# Altium schematic sheet DashboardDisplay.SchDoc(DashboardDisplay)
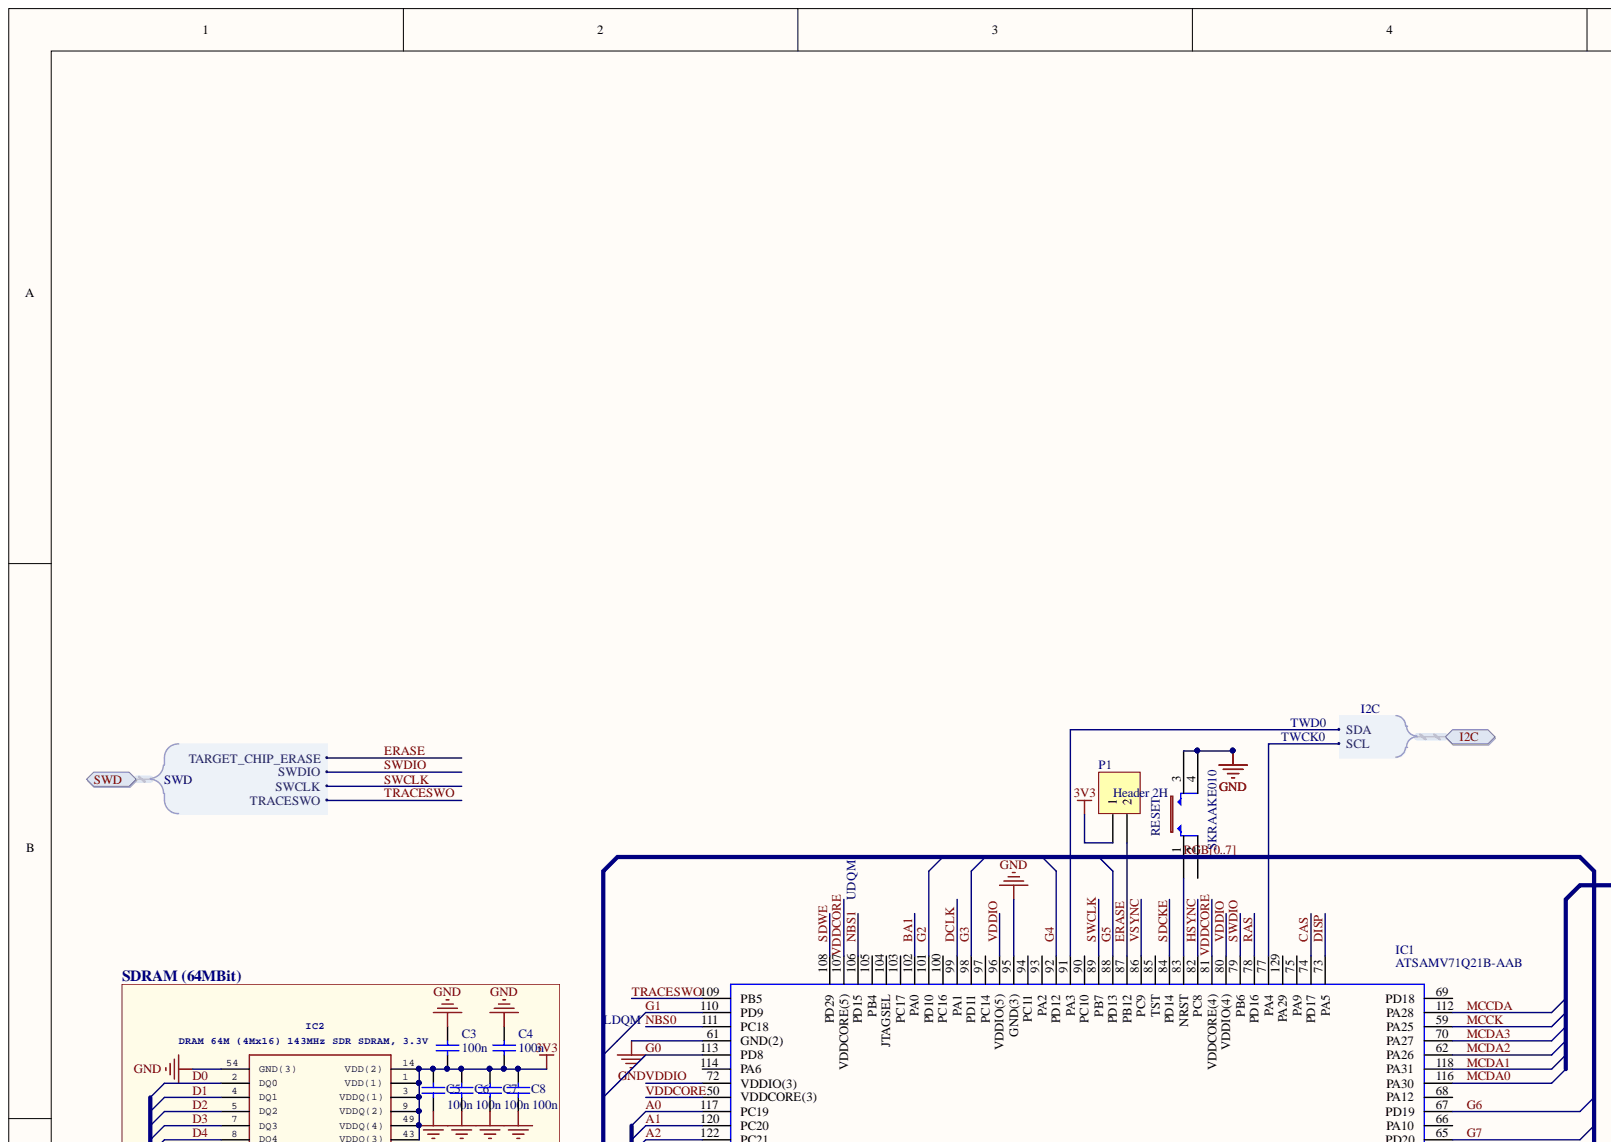
[diagram p0: DashboardDisplay.SchDoc, top left]
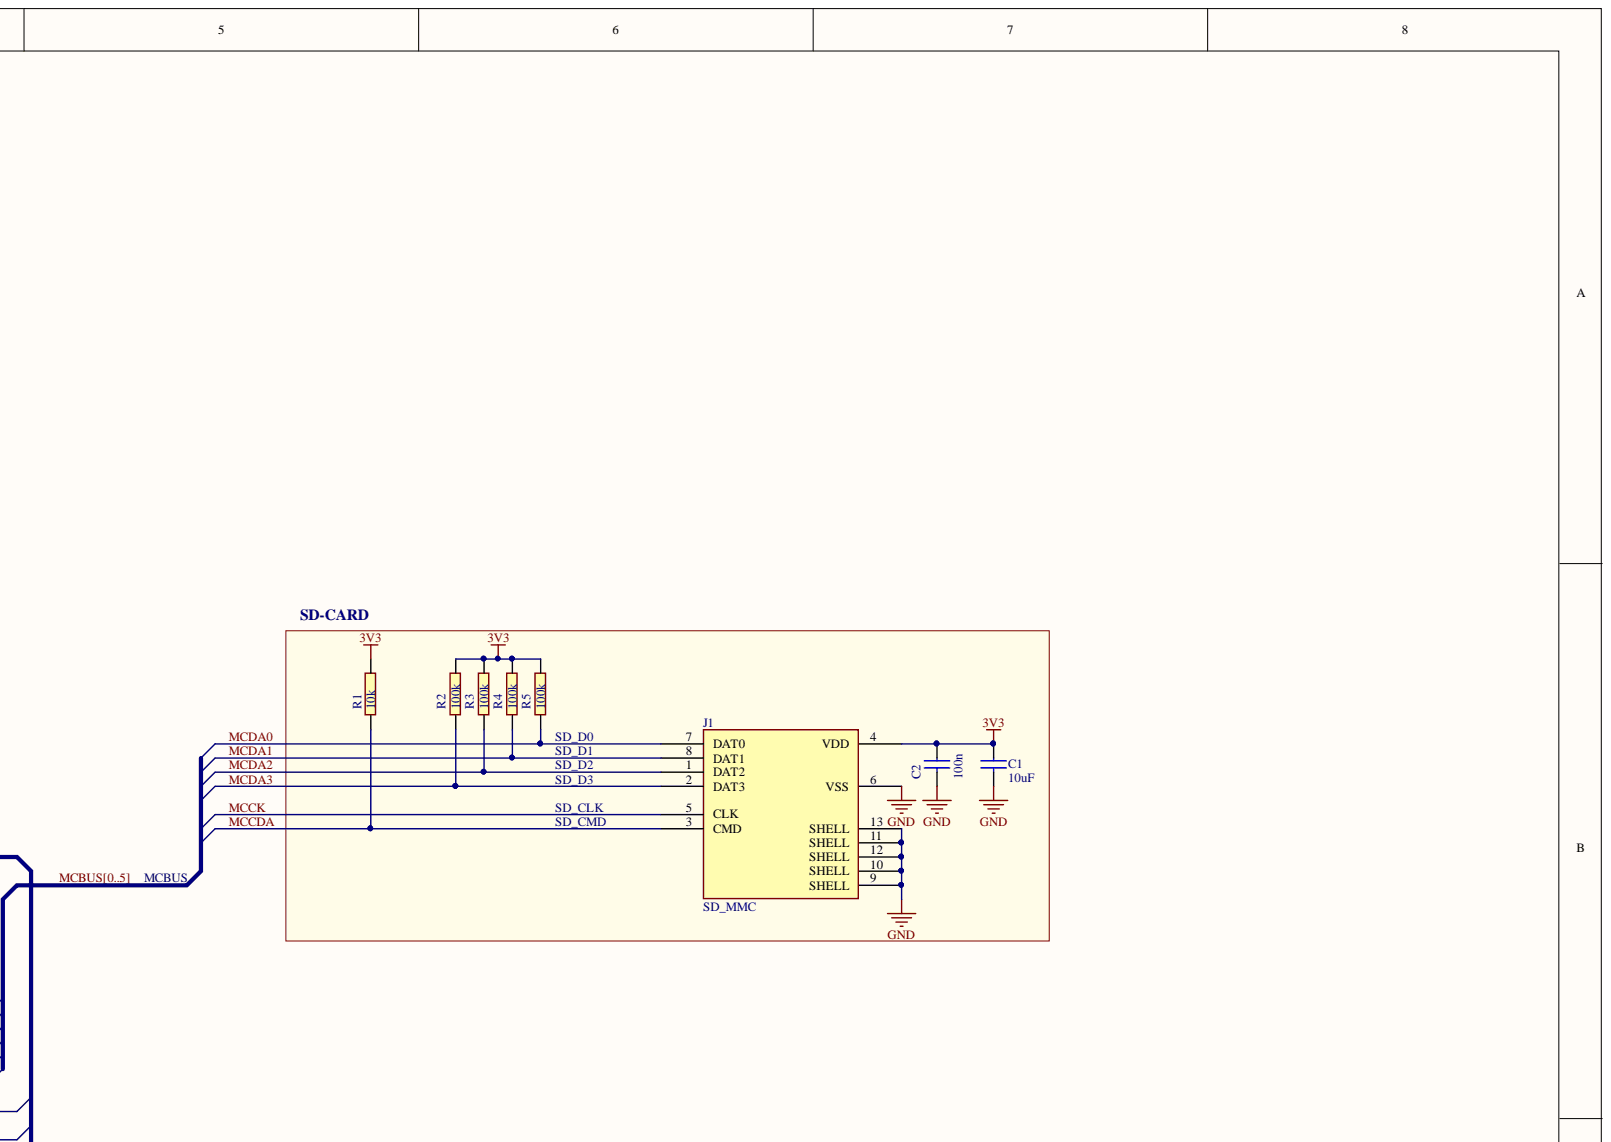
[diagram p1: DashboardDisplay.SchDoc, top right]
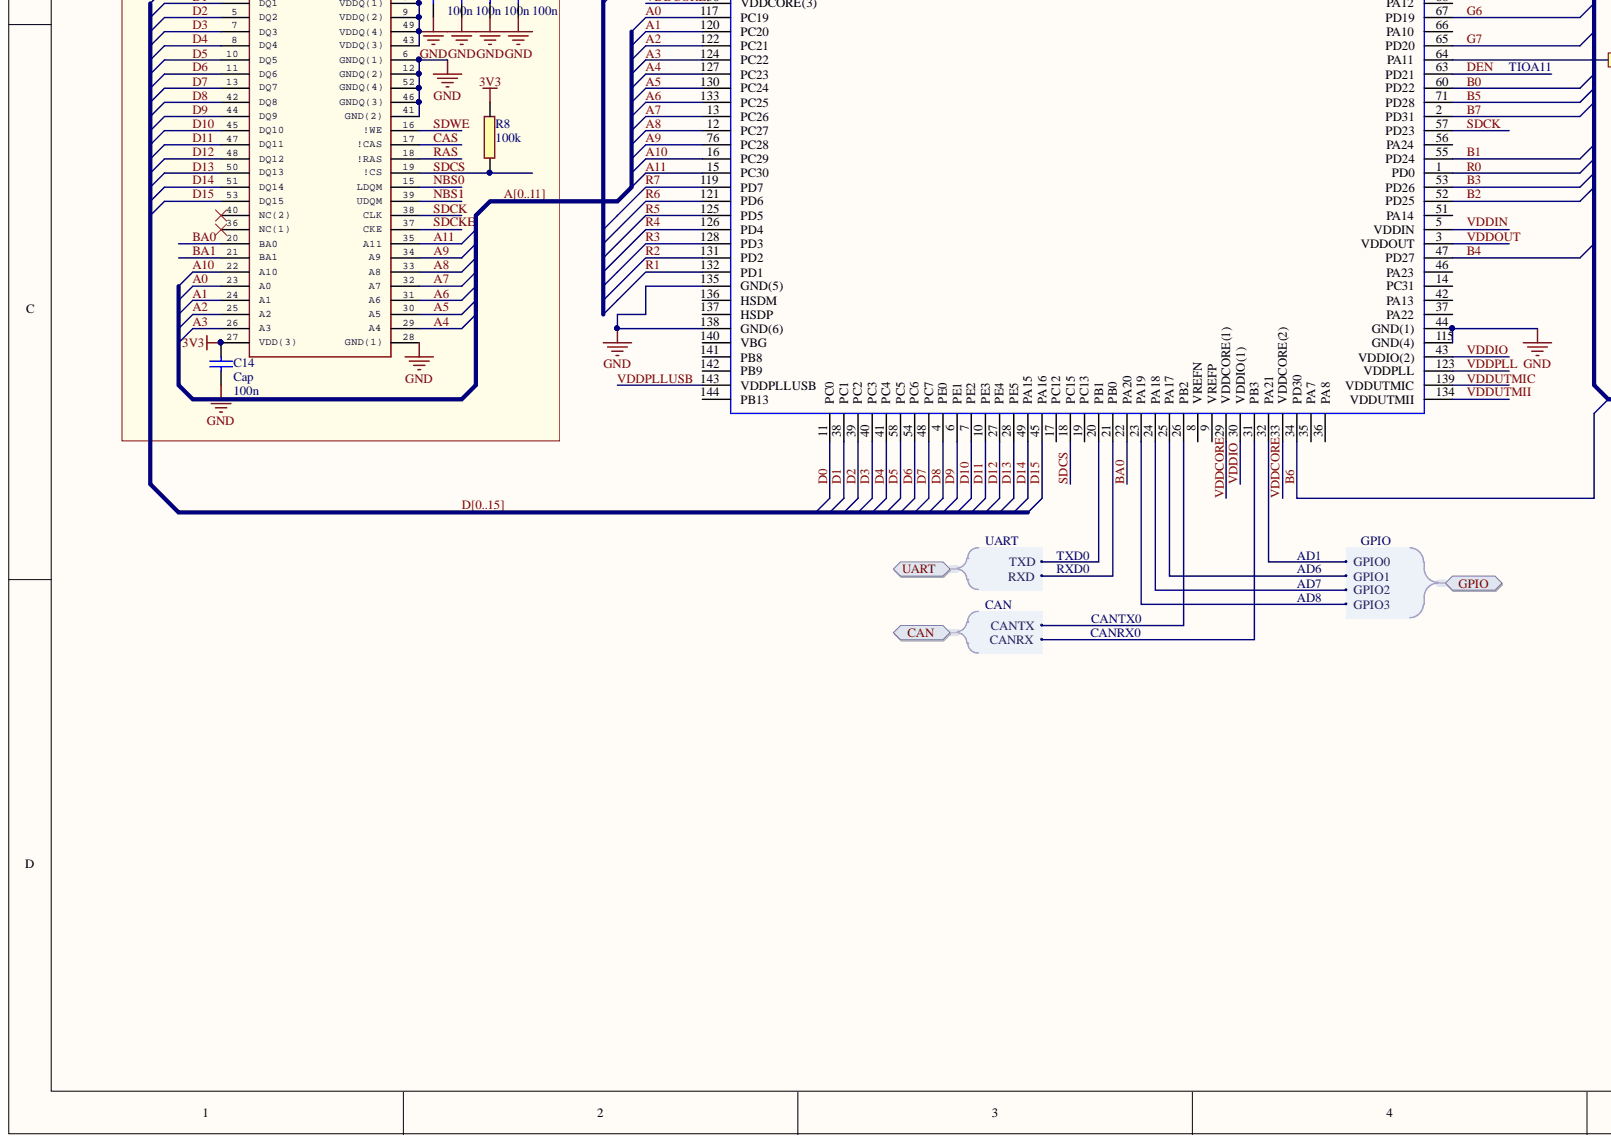
[diagram p2: DashboardDisplay.SchDoc, bottom left]
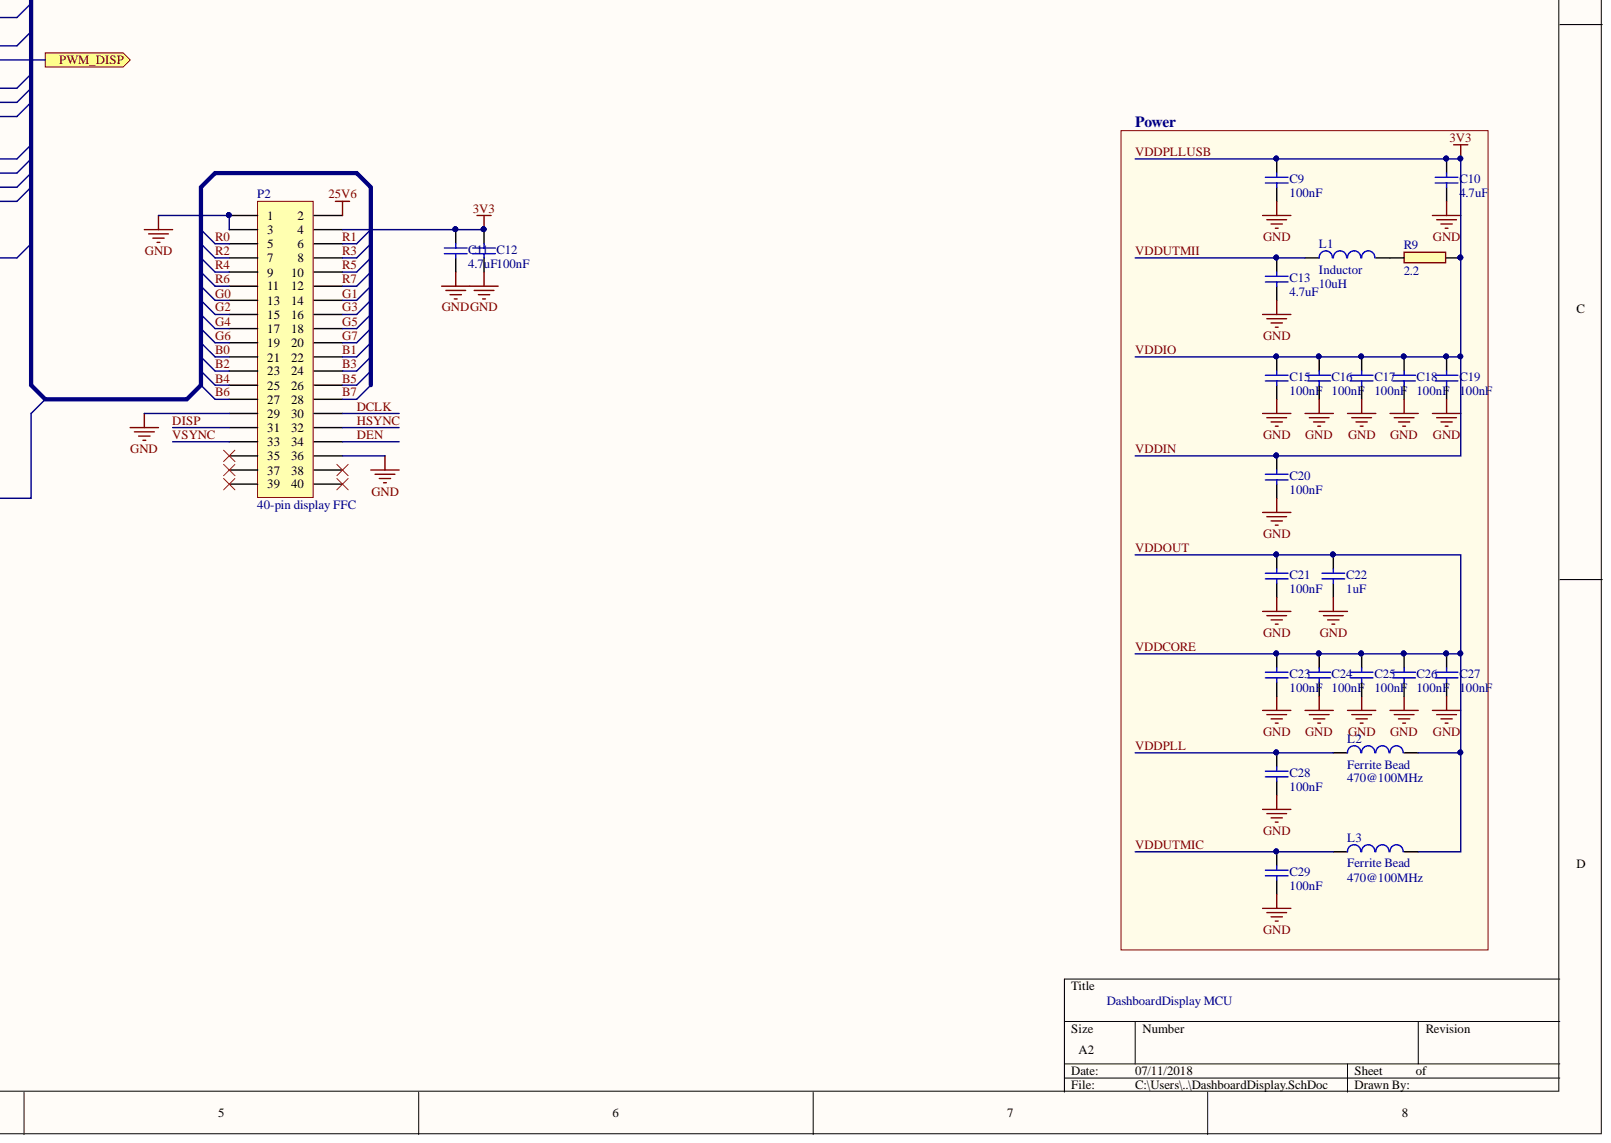
[diagram p3: DashboardDisplay.SchDoc, bottom right]

## Parts
C1  value 10uF  [C1206]
C2  value 100n  [1608[0603]]
C3  value 100n  [1608[0603]]
C4  value 100n  [1608[0603]]
C5  value 100n  [1608[0603]]
C6  value 100n  [1608[0603]]
C7  value 100n  [1608[0603]]
C8  value 100n  [1608[0603]]
C9  value 100nF  [1608[0603]]  (hidden comment: Cap Semi)
C10  value 4.7uF  [C1206]  (hidden comment: Cap Semi)
C11  value 4.7uF  [C1206]  (hidden comment: Cap Semi)
C12  value 100nF  [1608[0603]]  (hidden comment: Cap Semi)
C13  value 4.7uF  [C1206]  (hidden comment: Cap Semi)
C14  Cap  value 100n  [1608[0603]]
C15  value 100nF  [1608[0603]]  (hidden comment: Cap Semi)
C16  value 100nF  [1608[0603]]  (hidden comment: Cap Semi)
C17  value 100nF  [1608[0603]]  (hidden comment: Cap Semi)
C18  value 100nF  [1608[0603]]  (hidden comment: Cap Semi)
C19  value 100nF  [1608[0603]]  (hidden comment: Cap Semi)
C20  value 100nF  [1608[0603]]  (hidden comment: Cap Semi)
C21  value 100nF  [1608[0603]]  (hidden comment: Cap Semi)
C22  value 1uF  [1608[0603]]  (hidden comment: Cap Semi)
C23  value 100nF  [1608[0603]]  (hidden comment: Cap Semi)
C24  value 100nF  [1608[0603]]  (hidden comment: Cap Semi)
C25  value 100nF  [1608[0603]]  (hidden comment: Cap Semi)
C26  value 100nF  [1608[0603]]  (hidden comment: Cap Semi)
C27  value 100nF  [1608[0603]]  (hidden comment: Cap Semi)
C28  value 100nF  [1608[0603]]  (hidden comment: Cap Semi)
C29  value 100nF  [1608[0603]]  (hidden comment: Cap Semi)
IC1  ATSAMV71Q21B-AAB  [QFP50P2200X2200X160-144N]
IC2  DRAM 64M (4Mx16) 143MHz SDR SDRAM, 3.3V  [SOP80P1176X120-54N]
J1  SD_MMC  [DM3AT-SF-PEJM5(40)]
L1  Inductor  value 10uH  [1608[0603]]
L2  Ferrite Bead  value 470@100MHz  [INDC1608L]
L3  Ferrite Bead  value 470@100MHz  [INDC1608L]
P1  Header 2H  [14-1210]
P2  40-pin display FFC  [54132-4062_1]
R1  value 10k  [6-0805_L]
R2  value 100k  [6-0805_L]
R3  value 100k  [6-0805_L]
R4  value 100k  [6-0805_L]
R5  value 100k  [6-0805_L]
R8  value 100k  [6-0805_L]
R9  value 2.2  [6-0805_L]
RESET  value SKRAAKE010  [SKRAAKE010_1]

## Ports
CAN, CAN.CANRX, CAN.CANTX, GPIO, GPIO.GPIO0, GPIO.GPIO1, GPIO.GPIO2, GPIO.GPIO3, I2C, I2C.SCL, I2C.SDA, PWM_DISP, SWD, SWD.SWCLK, SWD.SWDIO, SWD.TARGET_CHIP_ERASE, SWD.TRACESWO, UART, UART.RXD, UART.TXD

## Nets
25V6: P2.2
GND: C1.2 C2.2 C3.2 C4.2 C5.1 C6.1 C7.1 C8.1 C9.1 C10.1 C11.1 C12.1 C13.1 C14.1 C15.1 C16.1 C17.1 C18.1 C19.1 C20.1 C21.1 C22.1 C23.1 C24.1 C25.1 C26.1 C27.1 C28.1 C29.1 IC1.44(GND(1)) IC1.61(GND(2)) IC1.95(GND(3)) IC1.115(GND(4)) IC1.135(GND(5)) IC1.138(GND(6)) IC2.6(GNDQ(1)) IC2.12(GNDQ(2)) IC2.28(GND(1)) IC2.41(GND(2)) IC2.46(GNDQ(3)) IC2.52(GNDQ(4)) IC2.54(GND(3)) J1.6(VSS) J1.9(SHELL) J1.10(SHELL) J1.11(SHELL) J1.12(SHELL) J1.13(SHELL) P2.1 P2.3 P2.29 P2.36 RESET.3 RESET.4
A0: IC1.117(PC19) IC2.23(A0)  [also labelled A]
A1: IC1.120(PC20) IC2.24(A1)  [also labelled A]
A2: IC1.122(PC21) IC2.25(A2)  [also labelled A]
A3: IC1.124(PC22) IC2.26(A3)  [also labelled A]
A4: IC1.127(PC23) IC2.29(A4)  [also labelled A]
A5: IC1.130(PC24) IC2.30(A5)  [also labelled A]
A6: IC1.133(PC25) IC2.31(A6)  [also labelled A]
A7: IC1.13(PC26) IC2.32(A7)  [also labelled A]
A8: IC1.12(PC27) IC2.33(A8)  [also labelled A]
A9: IC1.76(PC28) IC2.34(A9)  [also labelled A]
A10: IC1.16(PC29) IC2.22(A10)  [also labelled A]
A11: IC1.15(PC30) IC2.35(A11)  [also labelled A]
B0: IC1.60(PD22) P2.21
B1: IC1.55(PD24) P2.22
B2: IC1.52(PD25) P2.23
B3: IC1.53(PD26) P2.24
B4: IC1.47(PD27) P2.25
B5: IC1.71(PD28) P2.26
B6: IC1.34(PD30) P2.27
B7: IC1.2(PD31) P2.28
BA0: IC1.22(PA20) IC2.20(BA0)
BA1: IC1.102(PA0) IC2.21(BA1)
CAS: IC1.74(PD17) IC2.17(!CAS)
D0: IC1.11(PC0) IC2.2(DQ0)  [also labelled D]
D1: IC1.38(PC1) IC2.4(DQ1)  [also labelled D]
D2: IC1.39(PC2) IC2.5(DQ2)  [also labelled D]
D3: IC1.40(PC3) IC2.7(DQ3)  [also labelled D]
D4: IC1.41(PC4) IC2.8(DQ4)  [also labelled D]
D5: IC1.58(PC5) IC2.10(DQ5)  [also labelled D]
D6: IC1.54(PC6) IC2.11(DQ6)  [also labelled D]
D7: IC1.48(PC7) IC2.13(DQ7)  [also labelled D]
D8: IC1.4(PE0) IC2.42(DQ8)  [also labelled D]
D9: IC1.6(PE1) IC2.44(DQ9)  [also labelled D]
D10: IC1.7(PE2) IC2.45(DQ10)  [also labelled D]
D11: IC1.10(PE3) IC2.47(DQ11)  [also labelled D]
D12: IC1.27(PE4) IC2.48(DQ12)  [also labelled D]
D13: IC1.28(PE5) IC2.50(DQ13)  [also labelled D]
D14: IC1.49(PA15) IC2.51(DQ14)  [also labelled D]
D15: IC1.45(PA16) IC2.53(DQ15)  [also labelled D]
DCLK: IC1.99(PA1) P2.30
DEN: IC1.63(PD21) P2.34
DISP: IC1.73(PA5) P2.31
ERASE: IC1.87(PB12) P1.2 ; port SWD
G0: IC1.113(PD8) P2.13
G1: IC1.110(PD9) P2.14
G2: IC1.101(PD10) P2.15
G3: IC1.98(PD11) P2.16
G4: IC1.92(PD12) P2.17
G5: IC1.88(PD13) P2.18
G6: IC1.67(PD19) P2.19
G7: IC1.65(PD20) P2.20
HSYNC: IC1.82(PC8) P2.32
MCCDA: IC1.112(PA28) J1.3(CMD) R1.1
MCCK: IC1.59(PA25) J1.5(CLK)
MCDA0: IC1.116(PA30) J1.7(DAT0) R5.1
MCDA1: IC1.118(PA31) J1.8(DAT1) R4.1
MCDA2: IC1.62(PA26) J1.1(DAT2) R3.1
MCDA3: IC1.70(PA27) J1.2(DAT3) R2.1
NBS0: IC1.111(PC18) IC2.15(LDQM)
NBS1: IC1.106(PD15) IC2.39(UDQM)
PWM_DISP: IC1.64(PA11) ; port PWM_DISP
R0: IC1.1(PD0) P2.5
R1: IC1.132(PD1) P2.6
R2: IC1.131(PD2) P2.7
R3: IC1.128(PD3) P2.8
R4: IC1.126(PD4) P2.9
R5: IC1.125(PD5) P2.10
R6: IC1.121(PD6) P2.11
R7: IC1.119(PD7) P2.12
RAS: IC1.78(PD16) IC2.18(!RAS)
SDCK: IC1.57(PD23) IC2.38(CLK)
SDCKE: IC1.84(PD14) IC2.37(CKE)
SDCS: IC1.18(PC15) IC2.19(!CS) R8.1
SDWE: IC1.108(PD29) IC2.16(!WE)
SWCLK: IC1.89(PB7) ; port SWD
SWDIO: IC1.79(PB6) ; port SWD
TRACESWO: IC1.109(PB5) ; port SWD
VDDCORE: C21.2 C22.2 C23.2 C24.2 C25.2 C26.2 C27.2 IC1.3(VDDOUT) IC1.29(VDDCORE(1)) IC1.33(VDDCORE(2)) IC1.50(VDDCORE(3)) IC1.81(VDDCORE(4)) IC1.107(VDDCORE(5)) L2.2 L3.2
VDDIN: C1.1 C2.1 C3.1 C4.1 C5.2 C6.2 C7.2 C8.2 C9.2 C10.2 C11.2 C12.2 C14.2 C15.2 C16.2 C17.2 C18.2 C19.2 C20.2 IC1.5(VDDIN) IC1.30(VDDIO(1)) IC1.43(VDDIO(2)) IC1.72(VDDIO(3)) IC1.80(VDDIO(4)) IC1.96(VDDIO(5)) IC1.143(VDDPLLUSB) IC2.1(VDD(1)) IC2.3(VDDQ(1)) IC2.9(VDDQ(2)) IC2.14(VDD(2)) IC2.27(VDD(3)) IC2.43(VDDQ(3)) IC2.49(VDDQ(4)) J1.4(VDD) P1.1 P2.4 R1.2 R2.2 R3.2 R4.2 R5.2 R8.2 R9.1
VDDPLL: C28.2 IC1.123(VDDPLL) L2.1
VDDUTMIC: C29.2 IC1.139(VDDUTMIC) L3.1
VDDUTMII: C13.2 IC1.134(VDDUTMII) L1.1
VSYNC: IC1.86(PC9) P2.33
NetIC1_20: IC1.20(PB1) ; port UART
NetIC1_21: IC1.21(PB0) ; port UART
NetIC1_23: IC1.23(PA19) ; port GPIO
NetIC1_24: IC1.24(PA18) ; port GPIO
NetIC1_25: IC1.25(PA17) ; port GPIO
NetIC1_26: IC1.26(PB2) ; port CAN
NetIC1_31: IC1.31(PB3) ; port CAN
NetIC1_32: IC1.32(PA21) ; port GPIO
NetIC1_77: IC1.77(PA4) ; port I2C
NetIC1_83: IC1.83(NRST) RESET.1
NetIC1_91: IC1.91(PA3) ; port I2C
NetL1_2: L1.2 R9.2
MCBUS0:  [also labelled MCBUS]
MCBUS1:  [also labelled MCBUS]
MCBUS2:  [also labelled MCBUS]
MCBUS3:  [also labelled MCBUS]
MCBUS4:  [also labelled MCBUS]
MCBUS5:  [also labelled MCBUS]
RGB0:  [also labelled RGB]
RGB1:  [also labelled RGB]
RGB2:  [also labelled RGB]
RGB3:  [also labelled RGB]
RGB4:  [also labelled RGB]
RGB5:  [also labelled RGB]
RGB6:  [also labelled RGB]
RGB7:  [also labelled RGB]

Not connected: IC1 8(VREFN) 9(VREFP) 14(PC31) 17(PC12) 19(PC13) 35(PA7) 36(PA8) 37(PA22) 42(PA13) 46(PA23) 51(PA14) 56(PA24) 66(PA10) 68(PA12) 69(PD18) 75(PA9) 85(TST) 90(PC10) 93(PA2) 94(PC11) 97(PC14) 100(PC16) 103(PC17) 104(JTAGSEL) 105(PB4) 114(PA6) 129(PA29) 136(HSDM) 137(HSDP) 140(VBG) 141(PB8) 142(PB9) 144(PB13); IC2 36(NC(1)) 40(NC(2)); P2 35 37 38 39 40; RESET 2

## Library data of the parts
C1 = A01-0360: Ceramic capacitor, SMD 0805, X5R, 10V, 10 %, (de19441); PartGroup: Capacitors,Ceramic,SMD 0805; MPN: GRM21BR61A106KE19L; Manufacturer: Murata; Tolerance: 10%; TemperatureRange: -55 - +85 deg C; Database Table Name: AltiumComponents; Library Name: AltiumDbLib.DbLib; Height: 1,25; Component Kind: Standard; AN: A01-0360
C2 = A01-0246: Ceramic capacitor, SMD 0402, X7R, 16V, +/-10%; PartGroup: Capacitors,Ceramic,SMD 0402; MPN: C0402C104K4RACTU; Manufacturer: Kemet; TemperatureRange: -55 - +125 deg C; Database Table Name: AltiumComponents; Tolerance: 16V, +/-10%; Library Name: AltiumDbLib.DbLib; Height: 0,5; Component Kind: Standard; AN: A01-0246
C3-C29 = Cap Semi: Capacitor (Semiconductor SIM Model); Simulation: CAP; Signal Integrity: Cap Semi; Published: 8-Jun-2000; PackageReference: C1206; PackageDescription: Chip Capacitor; Library Name: Miscellaneous Devices.IntLib; LatestRevisionNote: IPC-7351 Footprint Added.; LatestRevisionDate: 29-May-2009; Component Kind: Standard; Publisher: Altium Limited
IC1 = ATSAMV71Q21B-AAB: ARM Microcontrollers - MCU 2MB FLASH 384KB SRAM LQFP144; Manufacturer_Name: Microchip; Geometry.Height: 1.6mm; Manufacturer_Part_Number: ATSAMV71Q21B-AAB; Datasheet Link: http://ww1.microchip.com/downloads/en/DeviceDoc/Atmel-44003-32-bit-Cortex-M7-Microcontroller-SAM-V71Q-SAM-V71N-SAM-V71J_Datasheet.pdf; Component Kind: Standard; Library Name: ATSAMV71Q21B-AABedit.SchLib
IC2 = IS42S16400J-7TL: Manufacturer_Name: Integrated Silicon Solution Inc.; GateName_1: G$1; SymbolName_1: IS42S16400J-7TL; RS_Part_Number: 8115125; Package: SOP80P1176X120-54N; Manufacturer_Part_Number: IS42S16400J-7TL; LibraryName: IS42S16400J-7TL; Library Name: IS42S16400J-7TL.SCHLIB; Height: 1.2mm; DeviceSetName: IS42S16400J-7TL; Component Kind: Standard
J1 = (no symbol name): SD/MMC socket, 2.7mm, push-push, SMD; PartGroup: Mechanical devices,Connectors,Memory cards; Manufacturer: Kyocera-elco; Value: KYOCERA - 14 5738 009 220 859+; TemperatureRange: -25 deg C to 90 deg C; AN: A08-0816; MPN: KYOCERA - 14 5738 009 220 859+; Height: 2,70000004768372; Component Kind: Standard; Library Name: DashboardDisplay.SCHLIB
L1 = Inductor: Inductor  MLZ1608N100LT000; Code_IEC: 1005; Simulation: INDUCTOR; Signal Integrity: Inductor; Published: 8-Jun-2000; PackageReference: 0402-A; PackageDescription: Chip Inductor; MPN: MLZ1608N100LT000; Library Name: Miscellaneous Devices.IntLib; LatestRevisionNote: IPC-7351 Footprint Added.; LatestRevisionDate: 29-May-2009; Component Kind: Standard; Code_JEITA: 0402; Publisher: Altium Limited
L2 L3 = Inductor: Ferrite Bead  470ohm @ 100MHz  BLM18PG471SN1D; Code_IEC: 1005; Simulation: INDUCTOR; Signal Integrity: Inductor; Published: 8-Jun-2000; PackageReference: 0402-A; PackageDescription: Chip Inductor; Library Name: Miscellaneous Devices.IntLib; LatestRevisionNote: IPC-7351 Footprint Added.; LatestRevisionDate: 29-May-2009; Component Kind: Standard; Code_JEITA: 0402; Publisher: Altium Limited
P1 = Header 2H: Header, 2-Pin, Right Angle; Signal Integrity: Connector; Library Name: Miscellaneous Connectors.IntLib; LatestRevisionNote: Re-released for DXP Platform.; LatestRevisionDate: 17-Jul-2002; Component Kind: Standard; Publisher: Altium Limited
P2 = Header 20X2H: FFC, 40-pin; Signal Integrity: Connector; Library Name: Miscellaneous Connectors.IntLib; LatestRevisionNote: Re-released for DXP Platform.; LatestRevisionDate: 17-Jul-2002; Component Kind: Standard; Publisher: Altium Limited
R1 = A02-0236: Thick film resistor, SMD 0402, 1/16W, 1%; PartGroup: Resistors,SMD,0402 1%; MPN: CRCW040210K0FKED; Manufacturer: vishay; TemperatureRange: -55 - +125 deg C; Database Table Name: AltiumComponents; Tolerance: 1 %; Library Name: AltiumDbLib.DbLib; Height: 0,349999994039536; Component Kind: Standard; AN: A02-0236
R2-R5 R8 R9 = A02-0260: Thick film resistor, SMD 0402, 1/16W, 1%; PartGroup: Resistors,SMD,0402 1%; MPN: CR10-1003-FK; Manufacturer: ASJ; TemperatureRange: -55ï¿½ to +125ï¿½C; Database Table Name: AltiumComponents; Tolerance: 1 %; Library Name: AltiumDbLib.DbLib; Height: 0,349999994039536; Component Kind: Standard; AN: A02-0260
RESET = A08-0790: 6.2x6.2 mm SMD tact switch, same as A08-0091 but less force is needed; PartGroup: Mechanical devices,Switches,SMD; Manufacturer: ALPS; TemperatureRange: -30 - +90 deg C; Database Table Name: AltiumComponents; MPN: SKRAAKE010; Library Name: AltiumDbLib.DbLib; Height: 5,09999990463257; Component Kind: Standard; AN: A08-0790
